# Altium schematic sheet GOST_A3_1 (1).SchDot
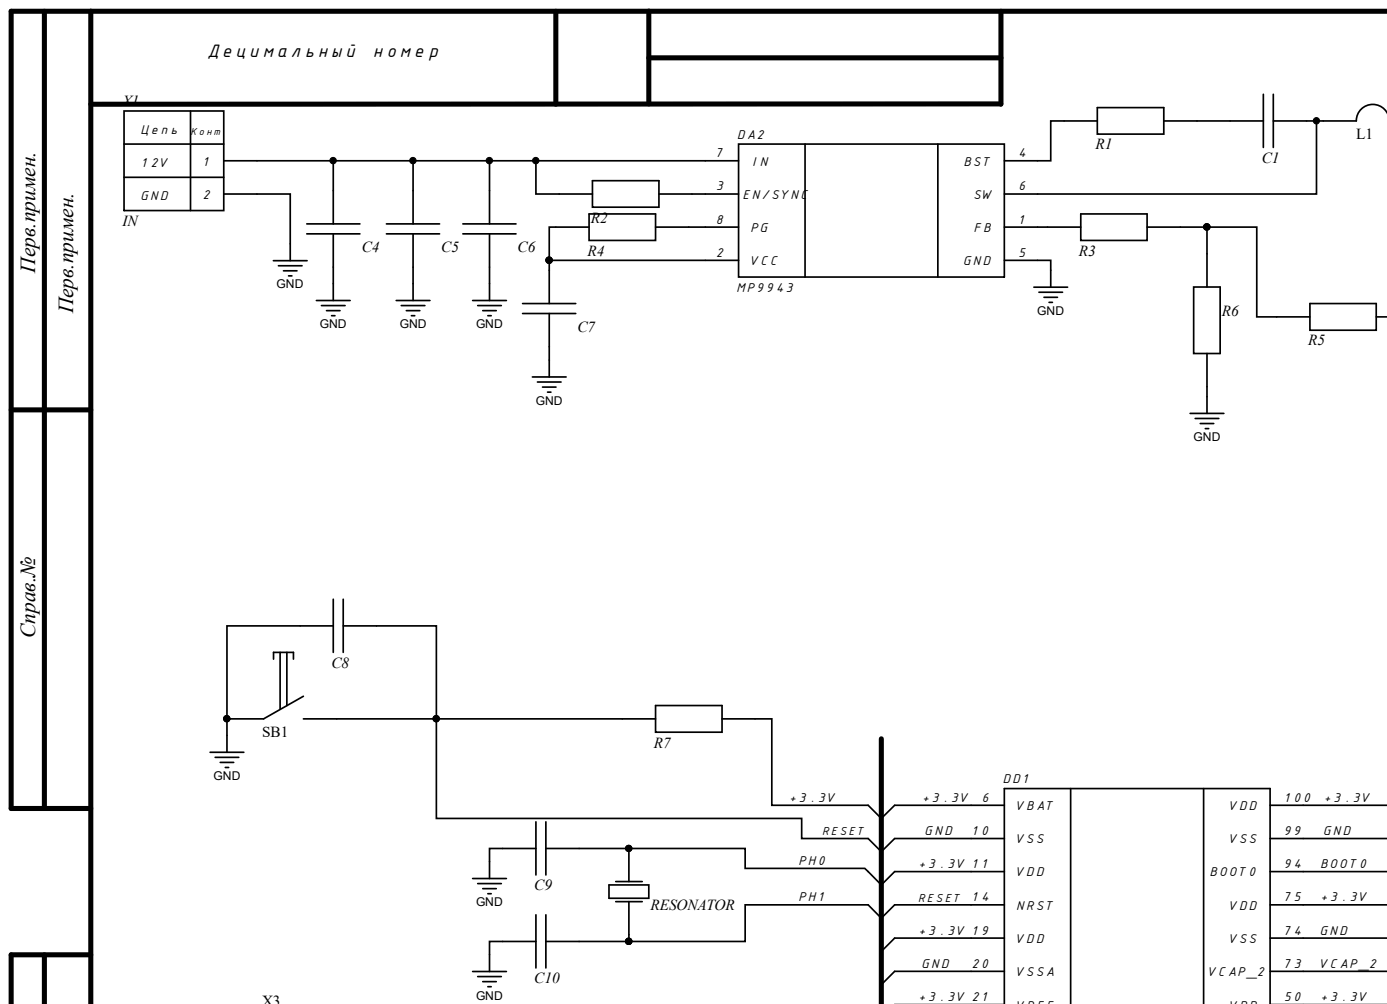
[diagram p0: GOST_A3_1 (1).SchDot, top left]
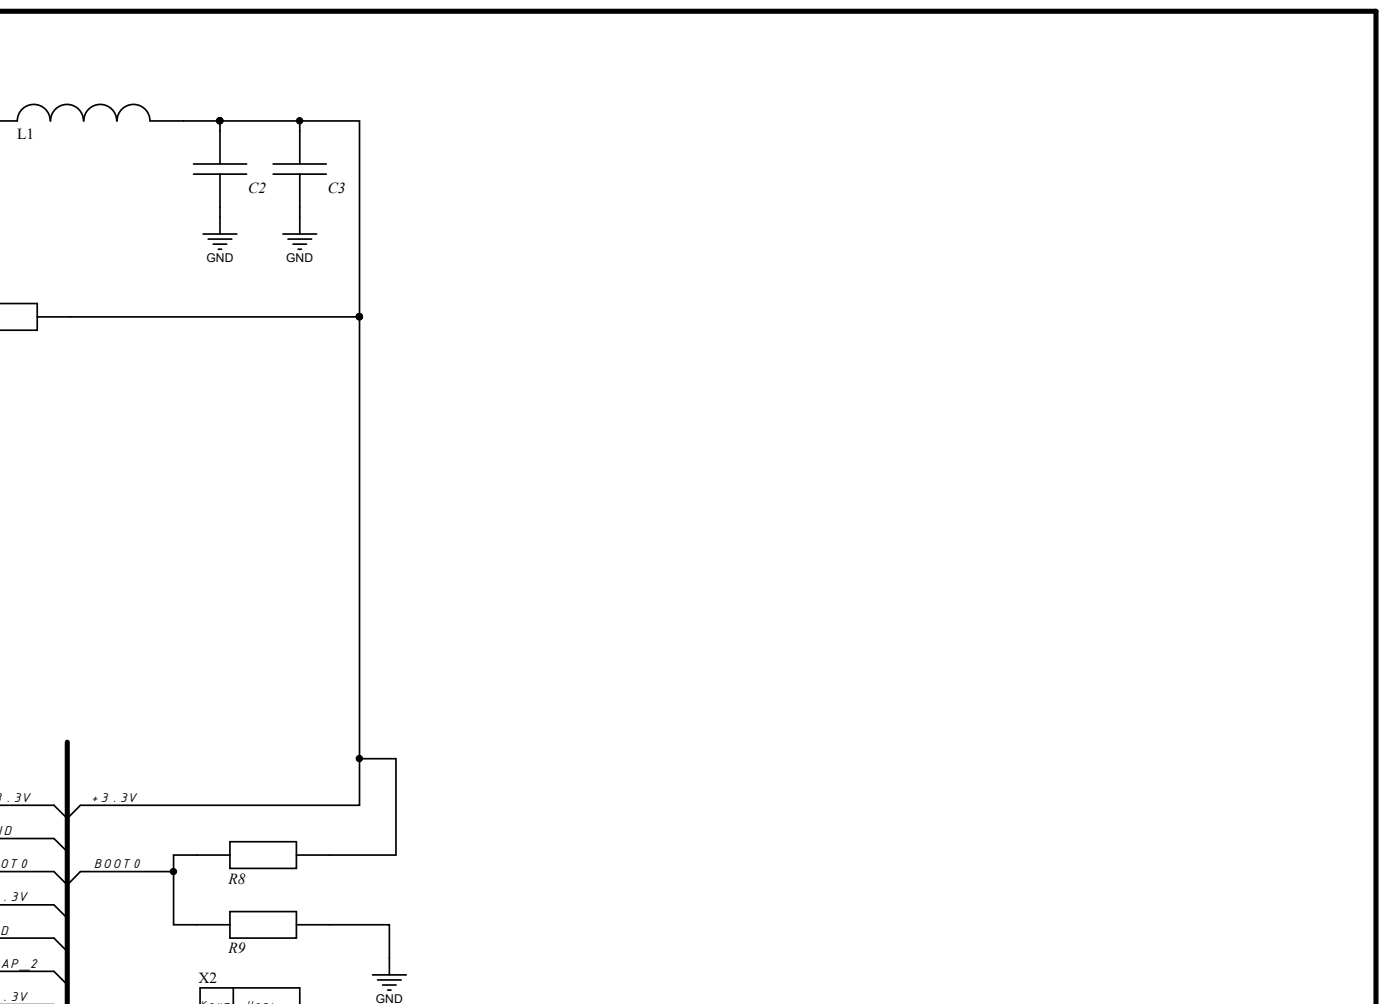
[diagram p1: GOST_A3_1 (1).SchDot, top right]
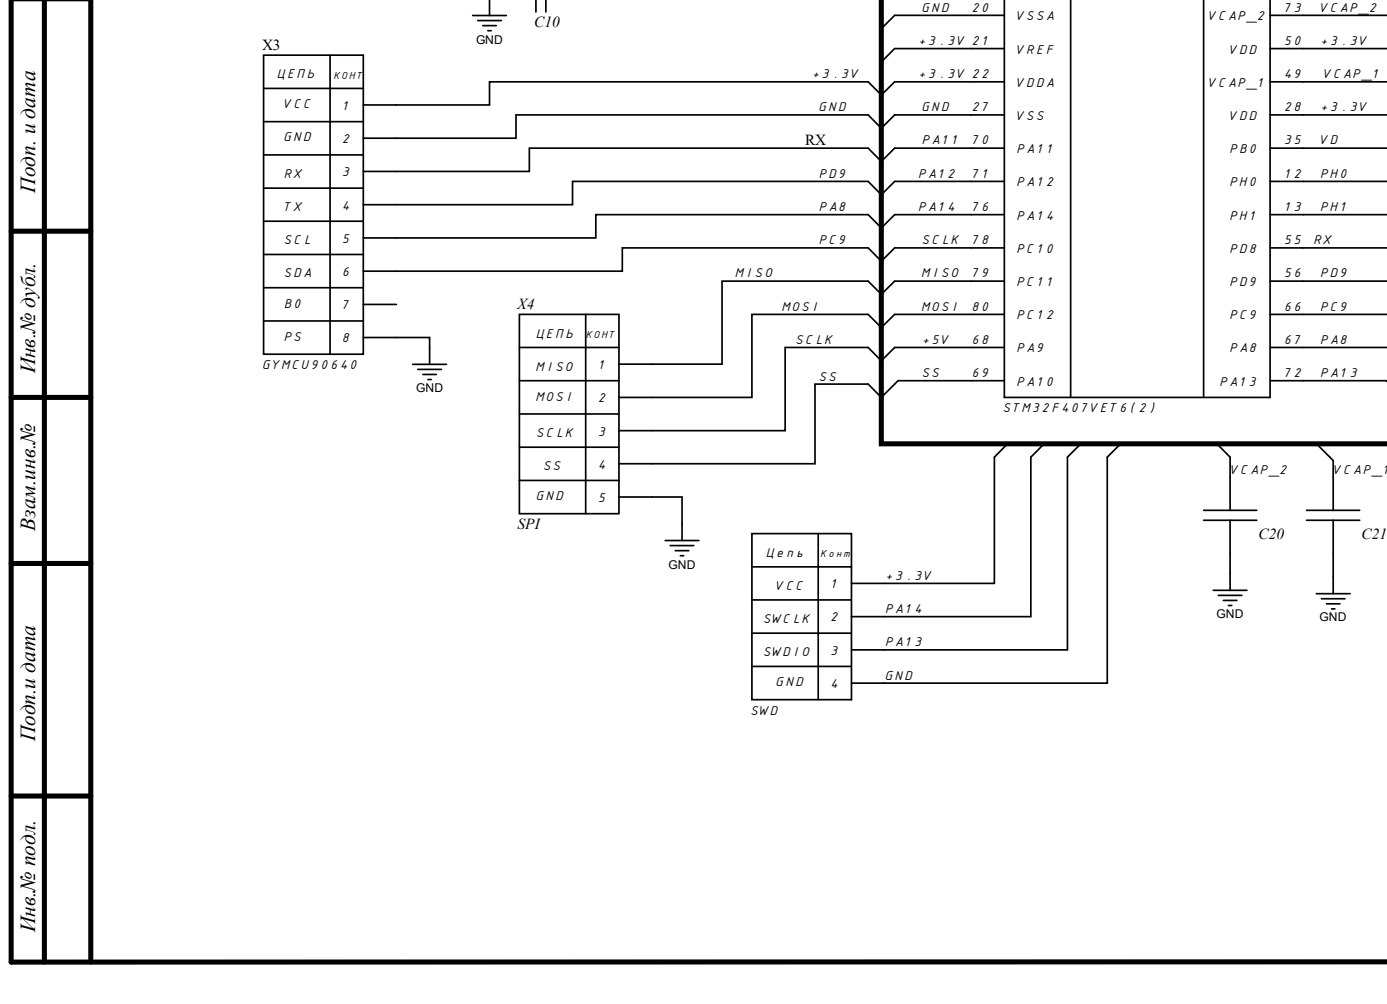
[diagram p2: GOST_A3_1 (1).SchDot, bottom left]
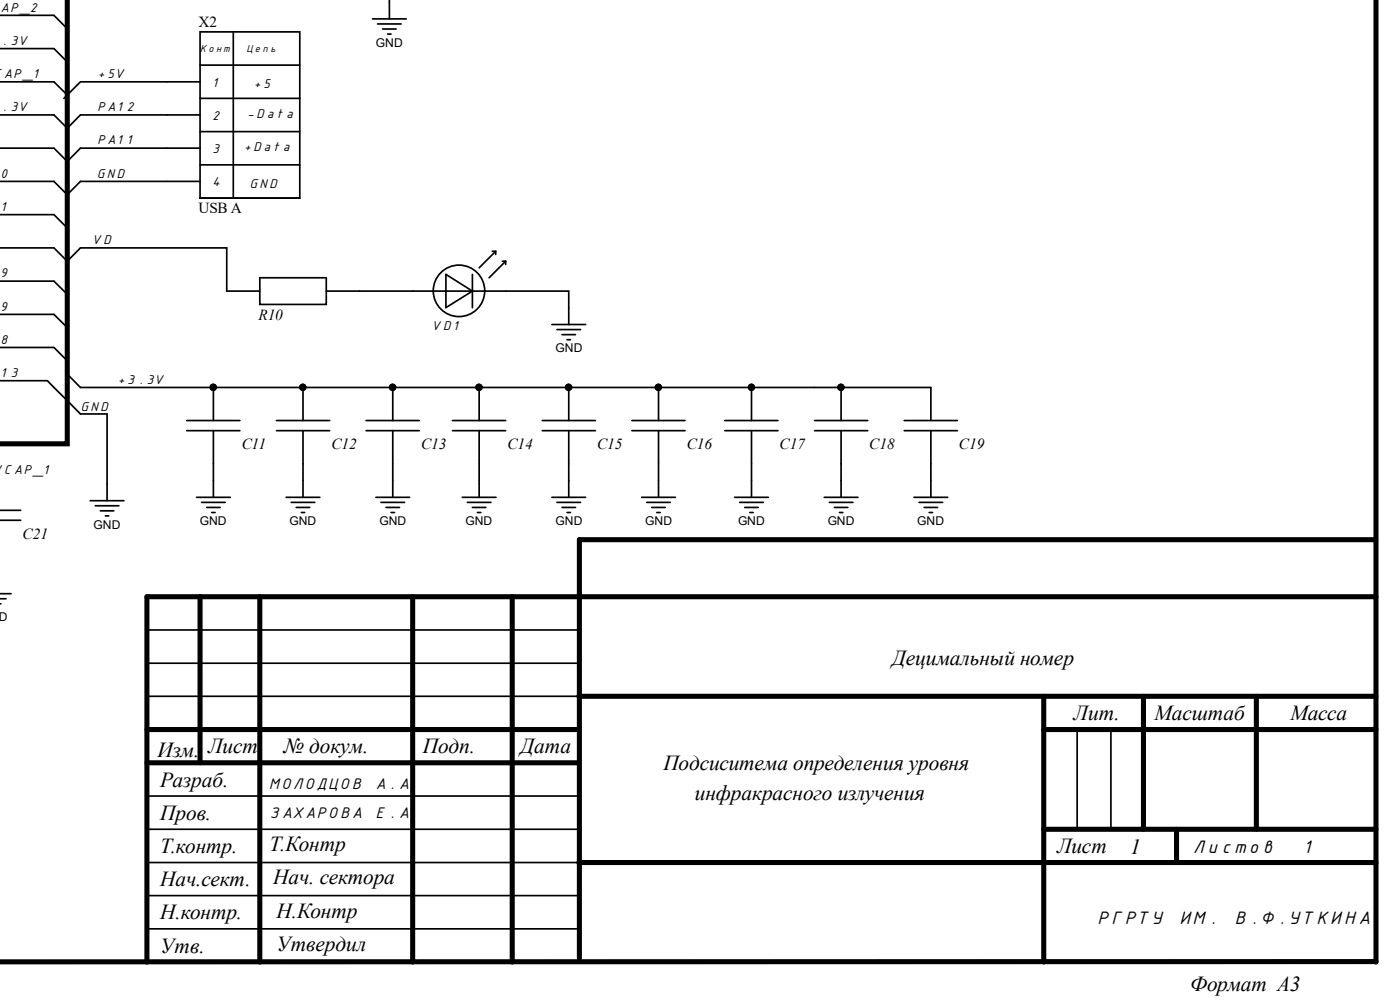
[diagram p3: GOST_A3_1 (1).SchDot, bottom right]

## Parts
C1  C
C2  C
C3  C
C4  C
C5  C
C6  C
C7  C
C8  C
C9  C
C10  C
C11  C
C12  C
C13  C
C14  C
C15  C
C16  C
C17  C
C18  C
C19  C
C20  C
C21  C
DA2  MP9943
DD1  STM32F407VET6(2)
L1  L
R1  R
R2  R
R3  R
R4  R
R5  R
R6  R
R7  R
R8  R
R9  R
R10  R
RES1  RESONATOR
SB1  SB
VD1  VD
X1  IN
X2  USB A
X3  GYMCU90640
X4  SPI
X5  SWD

## Nets
+3.3V: C2.2 C3.2 C11.2 C12.2 C13.2 C14.2 C15.2 C16.2 C17.2 C18.2 C19.2 DD1.6(VBAT) DD1.11(VDD) DD1.19(VDD) DD1.21(VREF) DD1.22(VDDA) DD1.28(VDD) DD1.50(VDD) DD1.75(VDD) DD1.100(VDD) L1.2 R5.2 R7.2 R8.2 X3.1 X5.1
+5V: DD1.68(PA9) X2.1
BOOT0: DD1.94(BOOT0) R8.1 R9.1
GND: C2.1 C3.1 C4.1 C5.1 C6.1 C7.1 C8.2 C9.2 C10.2 C11.1 C12.1 C13.1 C14.1 C15.1 C16.1 C17.1 C18.1 C19.1 C20.1 C21.1 DA2.5(GND) DD1.10(VSS) DD1.20(VSSA) DD1.27(VSS) DD1.74(VSS) DD1.99(VSS) R6.2 R9.2 SB1.1 VD1.2 X1.2 X2.4 X3.2 X3.8 X4.5 X5.4
MISO: DD1.79(PC11) X4.1
MOSI: DD1.80(PC12) X4.2
PA8: DD1.67(PA8) X3.5
PA11: DD1.70(PA11) X2.3
PA12: DD1.71(PA12) X2.2
PA13: DD1.72(PA13) X5.3
PA14: DD1.76(PA14) X5.2
PC9: DD1.66(PC9) X3.6
PD9: DD1.56(PD9) X3.4
PH0: C9.1 DD1.12(PH0) RES1.2
PH1: C10.1 DD1.13(PH1) RES1.1
RESET: C8.1 DD1.14(NRST) R7.1 SB1.2
RX: DD1.55(PD8) X3.3
SCLK: DD1.78(PC10) X4.3
SS: DD1.69(PA10) X4.4
VCAP_1: C21.2 DD1.49(VCAP_1)
VCAP_2: C20.2 DD1.73(VCAP_2)
VD: DD1.35(PB0) R10.1
NetC1_1: C1.1 DA2.6(SW) L1.1
NetC1_2: C1.2 R1.2
NetC4_2: C4.2 C5.2 C6.2 DA2.7(IN) R2.1 X1.1
NetC7_2: C7.2 DA2.2(VCC) R4.1
NetDA2_1: DA2.1(FB) R3.1
NetDA2_3: DA2.3(EN/SYNC) R2.2
NetDA2_4: DA2.4(BST) R1.1
NetDA2_8: DA2.8(PG) R4.2
NetR3_2: R3.2 R5.1 R6.1
NetR10_2: R10.2 VD1.1
N00007:

Not connected: X3 7
